# Altium schematic sheet SH7 - SD CARD CONNECTOR.SchDoc
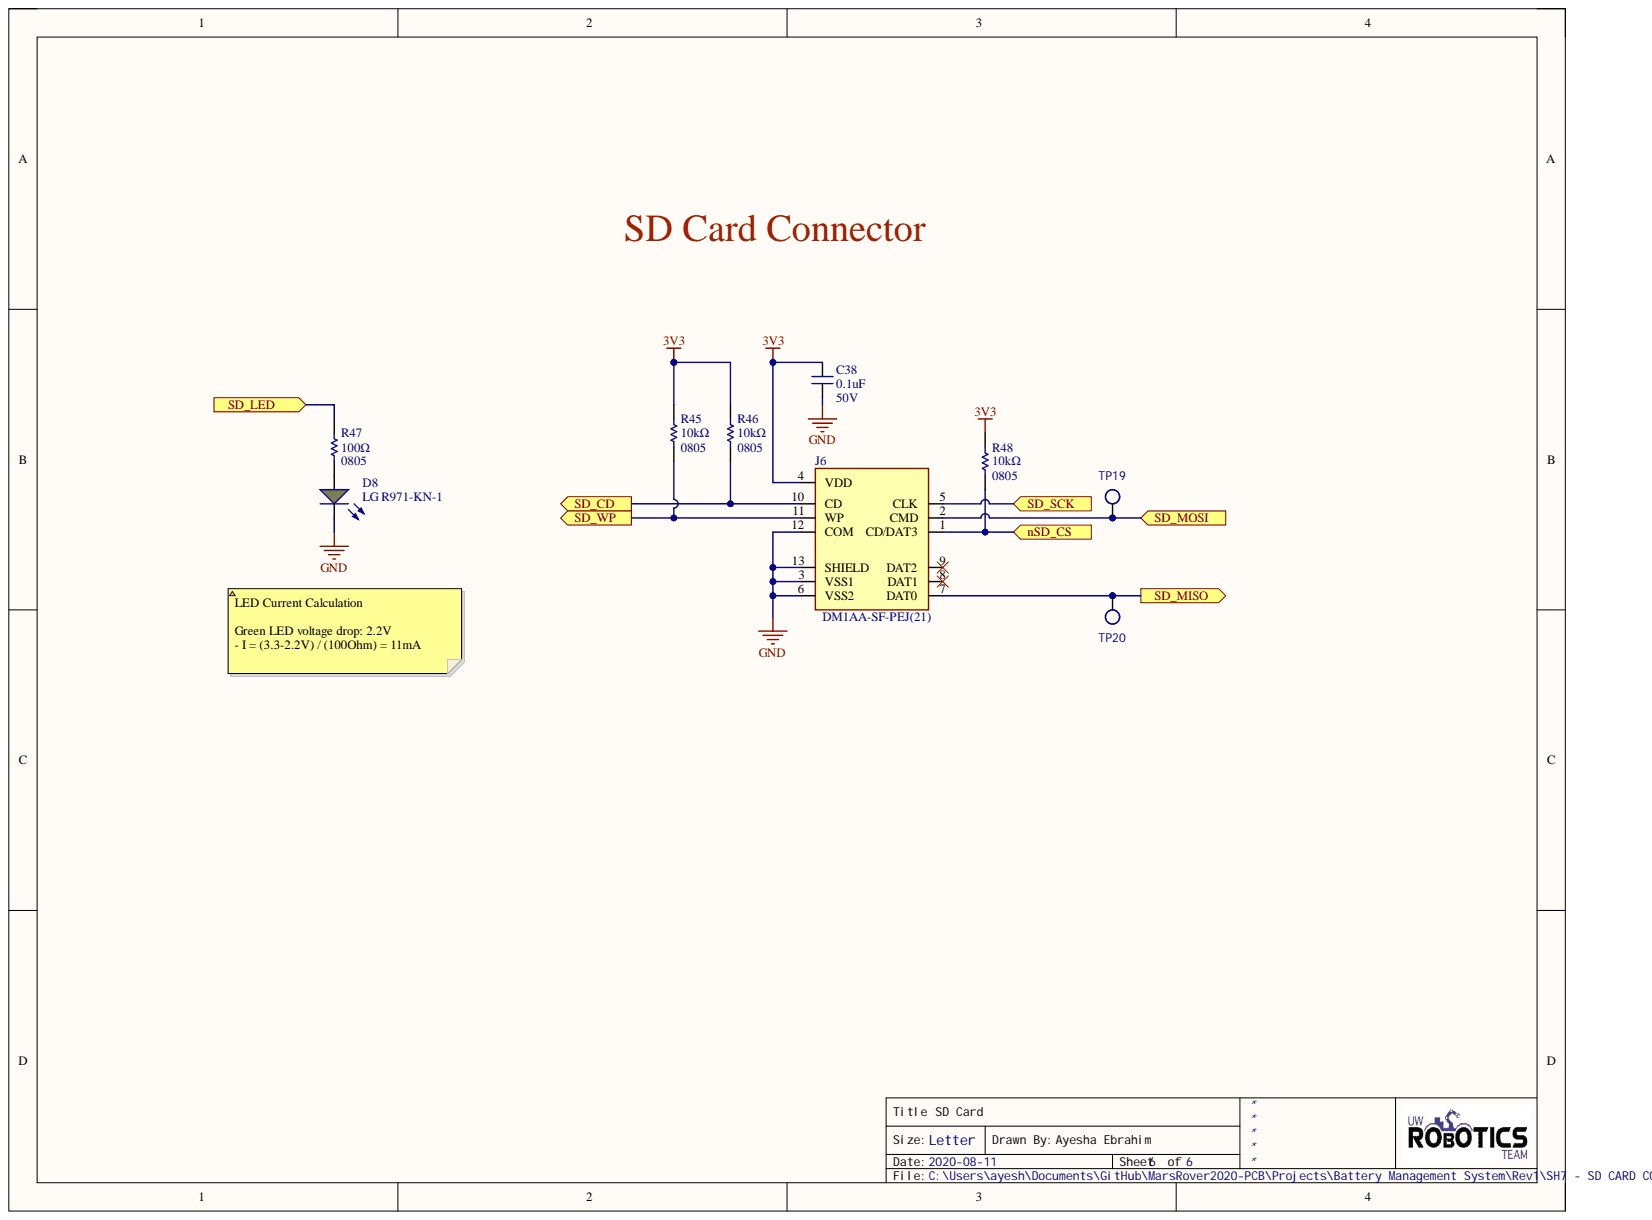
[diagram p0: SH7 - SD CARD CONNECTOR.SchDoc]

## Parts
C38  value 0.1uF  Voltage Rating: 50V  [CAPC2012X140N]  (hidden comment: CAP_0.1uF_50V_0805)
D8  Manufacturer Part Number 1: LG R971-KN-1  [LED_0805_LINE]  (hidden comment: DIO_LED_G)
J6  Manufacturer Part Number 1: DM1AA-SF-PEJ(21)  [HRS_DM1AA-SF-PEJ(21)]  (hidden comment: SD_CARD_CON)
R45  value 10kΩ  Case Code (Imperial): 0805  [RESC2012X60N]  (hidden comment: RES_10kΩ_0805)
R46  value 10kΩ  Case Code (Imperial): 0805  [RESC2012X60N]  (hidden comment: RES_10kΩ_0805)
R47  value 100Ω  Case Code (Imperial): 0805  [RESC2012X60N]  (hidden comment: RES_100Ω_0805)
R48  value 10kΩ  Case Code (Imperial): 0805  [RESC2012X60N]  (hidden comment: RES_10kΩ_0805)
TP19  TESTPOINT_LOOP  [TESTPOINT_LOOP]  (value not drawn)
TP20  TESTPOINT_LOOP  [TESTPOINT_LOOP]  (value not drawn)

## Ports
nSD_CS, SD_CD, SD_LED, SD_MISO, SD_MOSI, SD_SCK, SD_WP

## Nets
3V3: C38.2 J6.4(VDD) R45.2 R46.2 R48.2
GND: C38.1 D8.2 J6.3(VSS1) J6.6(VSS2) J6.12(COM) J6.13(SHIELD)
nSD_CS: J6.1(CD/DAT3) R48.1 ; port nSD_CS
SD_CD: J6.10(CD) R46.1 ; port SD_CD
SD_LED: R47.2 ; port SD_LED
SD_MISO: J6.7(DAT0) TP20.1 ; port SD_MISO
SD_MOSI: J6.2(CMD) TP19.1 ; port SD_MOSI
SD_SCK: J6.5(CLK) ; port SD_SCK
SD_WP: J6.11(WP) R45.1 ; port SD_WP
NetD8_1: D8.1 R47.1

Not connected: J6 8(DAT1) 9(DAT2)

## Library data of the parts
C38 = CAP_0.1uF_50V_0805: CAP CER 0.1UF 50V X7R 0805; Manufacturer 1: Murata Electronics; Manufacturer Part Number 1: GCD21BR71H104KA01L; Capacitance: 100nF; Tolerance: 10%; Case Code (Imperial): 0805; Case Code (Metric): 2012; Case/Package: 0805; Component Kind: Standard; ComponentLink1Description: https://datasheet.ciiva.com/29537/c03e-29537701.pdf?src-supplier=Digi-Key; ComponentLink1URL: https://datasheet.ciiva.com/29537/c03e-29537701.pdf?src-supplier=Digi-Key; Depth: 1.25mm; Dielectric: X7R; Height: 1.25mm; Length: 2mm; Max Operating Temperature: 125°C; Min Operating Temperature: -55°C; Mount: Surface Mount; Ratings: AEC-Q200; Supplier 1: Digi-Key; Supplier Part Number 1: 490-11955-1-ND; Termination: SMD/SMT; Thickness: 0.049inch; Width: 0.049inch; Library Name: UWRT_Schematic_Library_2020.SchLib
D8 = DIO_LED_G: LED GREEN DIFFUSED 0805 SMD; Manufacturer 1: OSRAM; Forward Voltage Drop: 2.2V; Component Kind: Standard; Mount: Surface Mount; Package: 0805 (2012 Metric); Simulation: LED1; Supplier 1: Digi-Key; Supplier Part Number 1: 475-1410-1-ND; Library Name: UWRT_Schematic_Library_2020.SchLib
J6 = SD_CARD_CON: 12 _9 + 3_ Position Card Connector Secure Digital - SD Surface Mount, Right Angle Gold; Manufacturer 1: Hirose Electric Co Ltd; Card Type: SD; Component Kind: Standard; Mount: Surface Mount; Supplier 1: Digi-Key; Supplier Part Number 1: HR845CT-ND; Library Name: UWRT_Schematic_Library_2020.SchLib
R45 R46 R48 = RES_10kΩ_0805: RES SMD 10K OHM 1% 1/8W 0805; Manufacturer 1: Yageo; Manufacturer Part Number 1: RC0805FR-0710KL; Power Rating: 125mW; Case Code (Metric): 2012; Component Kind: Standard; Max Operating Temperature: 155°C; Min Operating Temperature: -55°C; Resistance: 10K; Supplier 1: Digi-Key; Supplier Part Number 1: 311-10.0KCRCT-ND; Termination: SMD/SMT; Tolerance: 1%; Library Name: UWRT_Schematic_Library_2020.SchLib
R47 = RES_100Ω_0805: RES SMD 100 OHM 1% 1/8W 0805; Manufacturer 1: Yageo; Manufacturer Part Number 1: RC0805FR-07100RL; Power Rating: 125mW; Case Code (Metric): 2012; Component Kind: Standard; Mount: Surface Mount; Supplier 1: Digi-Key; Supplier Part Number 1: 311-100CRCT-ND; Library Name: UWRT_Schematic_Library_2020.SchLib
TP19 TP20 = TESTPOINT_LOOP: Loop testpoint through-hole pad; Manufacturer 1: Keystone Electronics; Manufacturer Part Number 1: 5002; Component Kind: Standard; Mount: Through Hole; Supplier 1: Digi-Key; Supplier Part Number 1: 36-5002-ND; Library Name: UWRT_Schematic_Library_2020.SchLib
